# Altium schematic sheet Bargraph.SchDoc
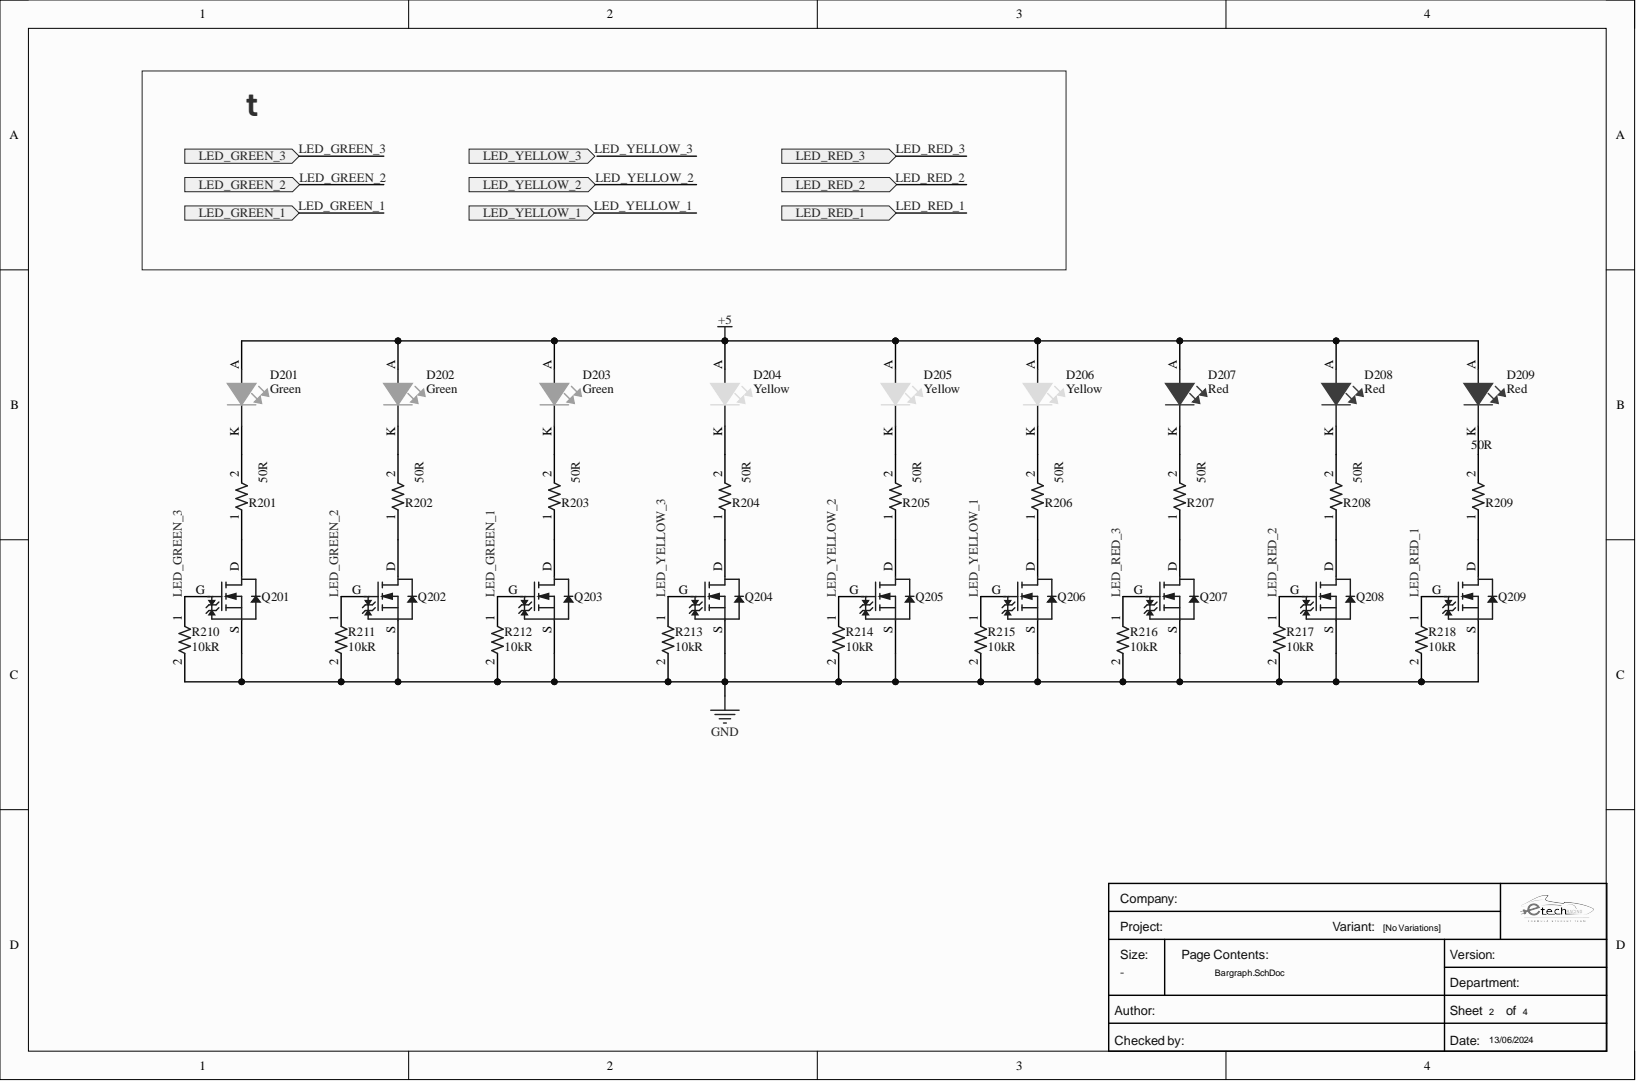
[diagram p0: Bargraph.SchDoc]

## Parts
D201  Color: Green  [LED-THT/WE/D3.9H10.5]  (hidden comment: 150080GS75000)
D202  Color: Green  [LED-THT/WE/D3.9H10.5]  (hidden comment: 150080GS75000)
D203  Color: Green  [LED-THT/WE/D3.9H10.5]  (hidden comment: 150080GS75000)
D204  Color: Yellow  [LED-THT/WE/D3.9H10.5]  (hidden comment: 150080YS75000)
D205  Color: Yellow  [LED-THT/WE/D3.9H10.5]  (hidden comment: 150080YS75000)
D206  Color: Yellow  [LED-THT/WE/D3.9H10.5]  (hidden comment: 150080YS75000)
D207  Color: Red  [LED-THT/WE/D3.9H10.5]  (hidden comment: 150080RS75000)
D208  Color: Red  [LED-THT/WE/D3.9H10.5]  (hidden comment: 150080RS75000)
D209  Color: Red  [LED-THT/WE/D3.9H10.5]  (hidden comment: 150080RS75000)
Q201  CPH3455-TL-H  [TRT-NCH-3/35-CPH]  (value not drawn)
Q202  CPH3455-TL-H  [TRT-NCH-3/35-CPH]  (value not drawn)
Q203  CPH3455-TL-H  [TRT-NCH-3/35-CPH]  (value not drawn)
Q204  CPH3455-TL-H  [TRT-NCH-3/35-CPH]  (value not drawn)
Q205  CPH3455-TL-H  [TRT-NCH-3/35-CPH]  (value not drawn)
Q206  CPH3455-TL-H  [TRT-NCH-3/35-CPH]  (value not drawn)
Q207  CPH3455-TL-H  [TRT-NCH-3/35-CPH]  (value not drawn)
Q208  CPH3455-TL-H  [TRT-NCH-3/35-CPH]  (value not drawn)
Q209  CPH3455-TL-H  [TRT-NCH-3/35-CPH]  (value not drawn)
R201  value 50R  [R0805FTK]  (hidden comment: CRCW080550R0FKTA)
R202  value 50R  [R0805FTK]  (hidden comment: CRCW080550R0FKTA)
R203  value 50R  [R0805FTK]  (hidden comment: CRCW080550R0FKTA)
R204  value 50R  [R0805FTK]  (hidden comment: CRCW080550R0FKTA)
R205  value 50R  [R0805FTK]  (hidden comment: CRCW080550R0FKTA)
R206  value 50R  [R0805FTK]  (hidden comment: CRCW080550R0FKTA)
R207  value 50R  [R0805FTK]  (hidden comment: CRCW080550R0FKTA)
R208  value 50R  [R0805FTK]  (hidden comment: CRCW080550R0FKTA)
R209  value 50R  [R0805FTK]  (hidden comment: CRCW080550R0FKTA)
R210  Resistance: 10kR  [R0805FTK]  (hidden comment: CR0805-JW-103ELF)
R211  Resistance: 10kR  [R0805FTK]  (hidden comment: CR0805-JW-103ELF)
R212  Resistance: 10kR  [R0805FTK]  (hidden comment: CR0805-JW-103ELF)
R213  Resistance: 10kR  [R0805FTK]  (hidden comment: CR0805-JW-103ELF)
R214  Resistance: 10kR  [R0805FTK]  (hidden comment: CR0805-JW-103ELF)
R215  Resistance: 10kR  [R0805FTK]  (hidden comment: CR0805-JW-103ELF)
R216  Resistance: 10kR  [R0805FTK]  (hidden comment: CR0805-JW-103ELF)
R217  Resistance: 10kR  [R0805FTK]  (hidden comment: CR0805-JW-103ELF)
R218  Resistance: 10kR  [R0805FTK]  (hidden comment: CR0805-JW-103ELF)

## Ports
LED_GREEN_1, LED_GREEN_2, LED_GREEN_3, LED_RED_1, LED_RED_2, LED_RED_3, LED_YELLOW_1, LED_YELLOW_2, LED_YELLOW_3

## Nets
+5: D201.A D202.A D203.A D204.A D205.A D206.A D207.A D208.A D209.A
GND: Q201.S Q202.S Q203.S Q204.S Q205.S Q206.S Q207.S Q208.S Q209.S R210.2 R211.2 R212.2 R213.2 R214.2 R215.2 R216.2 R217.2 R218.2
LED_GREEN_1: Q203.G R212.1
LED_GREEN_2: Q202.G R211.1 ; port LED_GREEN_2
LED_GREEN_3: Q201.G R210.1 ; port LED_GREEN_3
LED_RED_1: Q209.G R218.1 ; port LED_RED_1
LED_RED_2: Q208.G R217.1
LED_RED_3: Q207.G R216.1 ; port LED_RED_3
LED_YELLOW_1: Q206.G R215.1 ; port LED_YELLOW_1
LED_YELLOW_2: Q205.G R214.1 ; port LED_YELLOW_2
LED_YELLOW_3: Q204.G R213.1 ; port LED_YELLOW_3
NetD201_K: D201.K R201.2
NetD202_K: D202.K R202.2
NetD203_K: D203.K R203.2
NetD204_K: D204.K R204.2
NetD205_K: D205.K R205.2
NetD206_K: D206.K R206.2
NetD207_K: D207.K R207.2
NetD208_K: D208.K R208.2
NetD209_K: D209.K R209.2
NetQ201_D: Q201.D R201.1
NetQ202_D: Q202.D R202.1
NetQ203_D: Q203.D R203.1
NetQ204_D: Q204.D R204.1
NetQ205_D: Q205.D R205.1
NetQ206_D: Q206.D R206.1
NetQ207_D: Q207.D R207.1
NetQ208_D: Q208.D R208.1
NetQ209_D: Q209.D R209.1
N00001: ; port LED_GREEN_1
N00002: ; port LED_RED_2

## Library data of the parts
D201-D203 = LEDGS-THT: Led, Green, 520 Nm, 3.2 V, 30 Ma, 450 Mcd Rohs Compliant: Yes; Manufacturer 1: Wurth Electronics; .Creator: GP; Dominant Wavelength: 520nm; Forward Current: 30mA; Forward Voltage: 3.2V; Lens Style: Clear,Rectangular; Luminous Intensity: 450mcd; Test Current: 20mA; Viewing Angle: 140°; Case Code (Imperial): 0805; Case Code (Metric): 2012; Case/Package: 0805; Category: LEDs; Component Kind: Standard; ComponentLink1Description: Datasheet; ComponentLink1URL: https://www.we-online.com/catalog/datasheet/150080GS75000.pdf; ComponentLink2Description: Where buy it; ComponentLink2URL: https://katalog.we-online.de/en/led/WL-SMCW/150080GS75000; ComponentLink3Description: https://datasheet.ciiva.com/29563/150080gs75000-29563008.pdf?src-supplier=Digi-Key; ComponentLink3URL: https://datasheet.ciiva.com/29563/150080gs75000-29563008.pdf?src-supplier=Digi-Key; ComponentLink4Description: https://datasheet.ciiva.com/29279/759434-da-01-en-chipled-0805-w-kl-gruen-150080gs75000-29279039.pdf?src-supplier=Conrad; ComponentLink4URL: https://datasheet.ciiva.com/29279/759434-da-01-en-chipled-0805-w-kl-gruen-150080gs75000-29279039.pdf?src-supplier=Conrad; Height: 0.7mm; Length: 2mm; Library Name: Semiconductor.SchLib; Manufacturer Part Number 1: 150080GS75000; Max Operating Temperature: 85°C; Min Operating Temperature: -40°C; Mount: Surface Mount; Package Quantity: 4000; Packaging: Cut Tape; Pricing 1: 50=0,19300, 500=0,12900, 1000=0,12300, 2000=0,11700, 4000=0,11100 (USD); REACH SVHC: Unknown; RoHS: Yes; RoHS Compliant: Yes; Stock 1: 4350; Supplier 1: RSComponents; Supplier Part Number 1: 8154221; Wavelength: 520nm; Width: 1.25mm
D204-D206 = LEDYS-THT: 2 V Yellow LED 2012 (0805) SMD, Wurth Elektronik WL-SMCW 150080YS75000; Manufacturer 1: Wurth Electronics; .Creator: DR; Dominant Wavelength: 590nm; Forward Current: 30mA; Forward Voltage: 2V; Lens Style: Clear,Rectangular; Luminous Intensity: 120mcd; Test Current: 20mA; Viewing Angle: 140°; Case Code (Imperial): 0805; Case Code (Metric): 2012; Case/Package: 0805; Category: LEDs; Component Kind: Standard; ComponentLink1Description: Datasheet; ComponentLink1URL: https://www.we-online.com/catalog/datasheet/150080YS75000.pdf; ComponentLink2Description: Where buy it; ComponentLink2URL: https://katalog.we-online.de/en/led/WL-SMCW/150080YS75000; ComponentLink3Description: https://datasheet.ciiva.com/23843/150080ys75000-23843256.pdf?src-supplier=Newark; ComponentLink3URL: https://datasheet.ciiva.com/23843/150080ys75000-23843256.pdf?src-supplier=Newark; ComponentLink4Description: https://datasheet.ciiva.com/29279/759438-da-01-en-chipled-0805-w-kl-gelb-150080ys75000-29279034.pdf?src-supplier=Conrad; ComponentLink4URL: https://datasheet.ciiva.com/29279/759438-da-01-en-chipled-0805-w-kl-gelb-150080ys75000-29279034.pdf?src-supplier=Conrad; ComponentLink5Description: https://datasheet.ciiva.com/22656/1911353-22656971.pdf?src-supplier=Element14; ComponentLink5URL: https://datasheet.ciiva.com/22656/1911353-22656971.pdf?src-supplier=Element14; ComponentLink6Description: https://datasheet.ciiva.com/29563/150080ys75000-29563019.pdf?src-supplier=Digi-Key; ComponentLink6URL: https://datasheet.ciiva.com/29563/150080ys75000-29563019.pdf?src-supplier=Digi-Key; ComponentLink7Description: https://datasheet.ciiva.com/29563/150080ys75000-29563020.pdf?src-supplier=Digi-Key; ComponentLink7URL: https://datasheet.ciiva.com/29563/150080ys75000-29563020.pdf?src-supplier=Digi-Key; ComponentLink8Description: https://datasheet.ciiva.com/29563/150080ys75000-29563021.pdf?src-supplier=Digi-Key; ComponentLink8URL: https://datasheet.ciiva.com/29563/150080ys75000-29563021.pdf?src-supplier=Digi-Key; Height: 0.7mm; Length: 2mm; Library Name: Semiconductor.SchLib; Manufacturer Part Number 1: 150080YS75000; Max Operating Temperature: 85°C; Min Operating Temperature: -40°C; Mount: Surface Mount; Package Quantity: 4000; Packaging: Tape and Reel; Pricing 1: 50=0,19300, 500=0,12900, 750=0,12300, 1500=0,11700, 3000=0,11100 (USD); REACH SVHC: Unknown; RoHS: Yes; RoHS Compliant: Yes; Stock 1: 2100; Supplier 1: RSComponents; Supplier Part Number 1: 8154231; Wavelength: 590nm; Width: 1.25mm
D207-D209 = LEDRS-THT: 0805(H=0.8mm) 红色 高亮 λD=625nm VF=2V IF=30mA PD=72mW; Manufacturer 1: Wurth Electronics; .Creator: DR; Dominant Wavelength: 625nm; Forward Current: 30mA; Forward Voltage: 2V; Lens Style: Clear,Rectangular; Luminous Intensity: 150mcd; Test Current: 20mA; Viewing Angle: 140°; Case Code (Imperial): 0805; Case Code (Metric): 2012; Case/Package: 0805; Category: LEDs; Component Kind: Standard; ComponentLink1Description: Datasheet; ComponentLink1URL: https://www.we-online.com/catalog/datasheet/150080RS75000.pdf; ComponentLink2Description: Where buy it; ComponentLink2URL: https://katalog.we-online.de/en/led/WL-SMCW/150080RS75000; ComponentLink3Description: https://datasheet.ciiva.com/22656/150080rs75000-22656961.pdf?src-supplier=Element14; ComponentLink3URL: https://datasheet.ciiva.com/22656/150080rs75000-22656961.pdf?src-supplier=Element14; ComponentLink4Description: https://datasheet.ciiva.com/29279/759435-da-01-en-chipled-0805-w-kl-rot-150080rs75000-29279032.pdf?src-supplier=Conrad; ComponentLink4URL: https://datasheet.ciiva.com/29279/759435-da-01-en-chipled-0805-w-kl-rot-150080rs75000-29279032.pdf?src-supplier=Conrad; Height: 0.7mm; Length: 2mm; Library Name: Semiconductor.SchLib; Manufacturer Part Number 1: 150080RS75000; Max Operating Temperature: 85°C; Min Operating Temperature: -40°C; Mount: Surface Mount; Package Quantity: 4000; Packaging: Tape and Reel; Pricing 1: 50=0,19300, 500=0,12900, 1000=0,12300, 2000=0,11700, 4000=0,11100 (USD); REACH SVHC: Unknown; RoHS: Yes; RoHS Compliant: Yes; Stock 1: 1; Supplier 1: RSComponents; Supplier Part Number 1: 8154225P; Wavelength: 625nm; Width: 1.25mm
Q201-Q209 = TRT-NCH-3/35-CPH: CPH3455-TL-H N-channel MOSFET Transistor; 3 A; 35 V; 3-Pin CPH; Manufacturer: ON Semiconductor; .Creator: GP; Continuous Drain Current (ID): 3A; Drain to Source Breakdown Voltage: 35V; Drain to Source Resistance: 108mR; Element Configuration: Single; Fall Time: 5.7ns; Gate to Source Voltage (Vgs): 20V; Input Capacitance: 186pF; Max Power Dissipation: 1W; Rds On Max: 104mR; Rise Time: 4.7ns; Turn-Off Delay Time: 15ns; Turn-On Delay Time: 4.2ns; Case/Package: SC; Category: Transistor; Component Kind: Standard; ComponentLink1Description: Datasheet; ComponentLink1URL: https://docs.rs-online.com/5847/0900766b812d514d.pdf; ComponentLink2Description: Where buy it; ComponentLink2URL: https://es.rs-online.com/web/p/mosfet/8009989; ComponentLink3Description: https://datasheet.ciiva.com/947/cph3455-d-947201.pdf?src-supplier=Digi-Key; ComponentLink3URL: https://datasheet.ciiva.com/947/cph3455-d-947201.pdf?src-supplier=Digi-Key; ComponentLink4Description: https://datasheet.ciiva.com/4444/cph3455-d-91653-4444616.pdf?src-supplier=Mouser; ComponentLink4URL: https://datasheet.ciiva.com/4444/cph3455-d-91653-4444616.pdf?src-supplier=Mouser; ComponentLink5Description: https://datasheet.ciiva.com/11164/cph3455-d-11164889.pdf?src-supplier=Avnet; ComponentLink5URL: https://datasheet.ciiva.com/11164/cph3455-d-11164889.pdf?src-supplier=Avnet; Drain to Source Voltage (Vdss): 35V; Halogen Free: Halogen Free; Height: 0.9mm; Lead Free: Lead Free; Length: 2.9mm; Library Name: Semiconductor.SchLib; Manufacturer Part Number: CPH3455-TL-H; Max Operating Temperature: 150°C; Min Operating Temperature: -55°C; Number of Pins: 3; Package Quantity: 3000; Packaging: Tape and Reel; Polarity: N-CHANNEL; Power Dissipation: 1W; Pricing 1: 50=0,50400 (USD); RoHS: Yes; RoHS Compliant: Yes; Series: -; Stock 1: 1; Supplier 1: RSComponents; Supplier Part Number 1: 8009989; Width: 1.6mm
R201-R208 = R50-0805FTK: Res Thick Film 0805 50 Ohm 1% 0.125W(1/8W) ±100ppm/C Pad SMD Automotive T/R; Manufacturer: Vishay Dale; Power Rating: 125mW; Temperature Coefficient: 100ppm/°C; Value: 50R; Voltage Rating: 150V; Case Code (Imperial): 0805; Case Code (Metric): 2012; Case/Package: 0805; Category: Chip SMD Resistors; Component Kind: Standard; ComponentLink1Description: https://datasheet.ciiva.com/48525/dcrcw-48525895.pdf?src-supplier=Avnet; ComponentLink1URL: https://datasheet.ciiva.com/48525/dcrcw-48525895.pdf?src-supplier=Avnet; Contact Plating: Tin,Lead; Height: 0.45mm; Lead Free: Contains Lead; Length: 2mm; Library Name: Passives.SchLib; Manufacturer Part Number: CRCW080550R0FKTA; Max Operating Temperature: 155°C; Min Operating Temperature: -55°C; Package Quantity: 5000; Packaging: Tape and Reel; Pricing 1: 1=0,25, 10=0,204, 50=0,204, 100=0,08, 1000=0,033, 10000=0,026 (USD); RoHS: No; RoHS Compliant: No; Series: CRCW; Stock 1: 38245; Supplier 1: Mouser; Supplier Part Number 1: 71-CRCW0805-50; Tolerance: 1%; Weight: 0.000194oz; Width: 1.25mm
R209 = R50-0805FTK: Res Thick Film 0805 50 Ohm 1% 0.125W(1/8W) ±100ppm/C Pad SMD Automotive T/R; Manufacturer: Vishay Dale; Power Rating: 125mW; Temperature Coefficient: 100ppm/°C; Voltage Rating: 150V; Case Code (Imperial): 0805; Case Code (Metric): 2012; Case/Package: 0805; Category: Chip SMD Resistors; Component Kind: Standard; ComponentLink1Description: https://datasheet.ciiva.com/48525/dcrcw-48525895.pdf?src-supplier=Avnet; ComponentLink1URL: https://datasheet.ciiva.com/48525/dcrcw-48525895.pdf?src-supplier=Avnet; Contact Plating: Tin,Lead; Height: 0.45mm; Lead Free: Contains Lead; Length: 2mm; Library Name: Passives.SchLib; Manufacturer Part Number: CRCW080550R0FKTA; Max Operating Temperature: 155°C; Min Operating Temperature: -55°C; Package Quantity: 5000; Packaging: Tape and Reel; Pricing 1: 1=0,25, 10=0,204, 50=0,204, 100=0,08, 1000=0,033, 10000=0,026 (USD); RoHS: No; RoHS Compliant: No; Series: CRCW; Stock 1: 38245; Supplier 1: Mouser; Supplier Part Number 1: 71-CRCW0805-50; Tolerance: 1%; Weight: 0.000194oz; Width: 1.25mm
R210-R218 = R10k-0805FTK: BOURNS         CR0805-JW-103ELF            Surface Mount Chip Resistor, Thick Film, CR Series, 10 kohm, 125 mW,  5%, 150 V; .Creator: DR; Max Power Dissipation: 125mW; Temperature Coefficient: 200ppm/°C; Voltage Rating: 150V; Voltage Rating (DC): 100V; Case Code (Imperial): 0805; Case Code (Metric): 2012; Case/Package: 0805; Category: Chip SMD Resistors; Component Kind: Standard; ComponentLink1Description: https://datasheet.ciiva.com/11821/0900766b80fcdb72-11821135.pdf?src-supplier=RSComponents; ComponentLink1URL: https://datasheet.ciiva.com/11821/0900766b80fcdb72-11821135.pdf?src-supplier=RSComponents; ComponentLink2Description: https://datasheet.ciiva.com/9122/chpreztr-9122374.pdf?src-supplier=Avnet; ComponentLink2URL: https://datasheet.ciiva.com/9122/chpreztr-9122374.pdf?src-supplier=Avnet; ComponentLink3Description: https://datasheet.ciiva.com/22693/1902866-22693254.pdf?src-supplier=Element14; ComponentLink3URL: https://datasheet.ciiva.com/22693/1902866-22693254.pdf?src-supplier=Element14; ComponentLink4Description: https://datasheet.ciiva.com/7986/691949-7986187.pdf?src-supplier=Element14; ComponentLink4URL: https://datasheet.ciiva.com/7986/691949-7986187.pdf?src-supplier=Element14; ComponentLink5Description: https://datasheet.ciiva.com/30065/159chpreztr-30065976.pdf?src-supplier=Onlinecomponents.com; ComponentLink5URL: https://datasheet.ciiva.com/30065/159chpreztr-30065976.pdf?src-supplier=Onlinecomponents.com; ComponentLink6Description: https://datasheet.ciiva.com/16783/70154685-16783482.pdf?src-supplier=Allied+Electronics; ComponentLink6URL: https://datasheet.ciiva.com/16783/70154685-16783482.pdf?src-supplier=Allied+Electronics; ComponentLink7Description: https://datasheet.ciiva.com/5548/chpreztr-5548491.pdf?src-supplier=Mouser; ComponentLink7URL: https://datasheet.ciiva.com/5548/chpreztr-5548491.pdf?src-supplier=Mouser; ComponentLink8Description: https://datasheet.ciiva.com/6559/chpreztr-6559900.pdf?src-supplier=Digi-Key; ComponentLink8URL: https://datasheet.ciiva.com/6559/chpreztr-6559900.pdf?src-supplier=Digi-Key; ComponentLink9Description: https://datasheet.ciiva.com/6559/chpreztr-6559901.pdf?src-supplier=Digi-Key; ComponentLink9URL: https://datasheet.ciiva.com/6559/chpreztr-6559901.pdf?src-supplier=Digi-Key; ComponentLink10Description: https://datasheet.ciiva.com/7985/1856384-7985869.pdf?src-supplier=Element14; ComponentLink10URL: https://datasheet.ciiva.com/7985/1856384-7985869.pdf?src-supplier=Element14; ComponentLink11Description: https://datasheet.ciiva.com/48692/001055517-da-01-en-smd-wid-cr0805-jw-103elf-10k-ohm-1-8w-48692118.pdf?src-supplier=Conrad; ComponentLink11URL: https://datasheet.ciiva.com/48692/001055517-da-01-en-smd-wid-cr0805-jw-103elf-10k-ohm-1-8w-48692118.pdf?src-supplier=Conrad; Construction: Rectangular; Contact Plating: Tin; Depth: 1.25mm; Features: Moisture Resistant; Height: 0.5mm; Length: 2mm; Library Name: Passives.SchLib; Manufacturer 1: Bourns; Manufacturer Part Number 1: CR0805-JW-103ELF; Max Operating Temperature: 155°C; Military Standard: Not; Min Operating Temperature: -55°C; Mount: Surface Mount; Number of Pins: 2; Number of Terminations: 2; Package Quantity: 1; Packaging: Tape and Reel; Power Rating: 125mW; Pricing 1: 1=0,05790, 10=0,01540, 25=0,01340, 100=0,00340 (USD); Radiation Hardening: No; REACH SVHC: No SVHC; Resistance Tolerance: 5%; Resistor Type: Thick Film; RoHS: Yes; RoHS Compliant: Yes; Series: CR0805; Stock 1: 57566; Supplier 1: Arrow Electronics; Supplier Part Number 1: CR0805-JW-103ELF; Technology: THICK FILM; Termination: SMD/SMT; Thickness: 508um; Tolerance: 5%; Width: 1.25mm
